# Altium schematic sheet Main.SchDoc
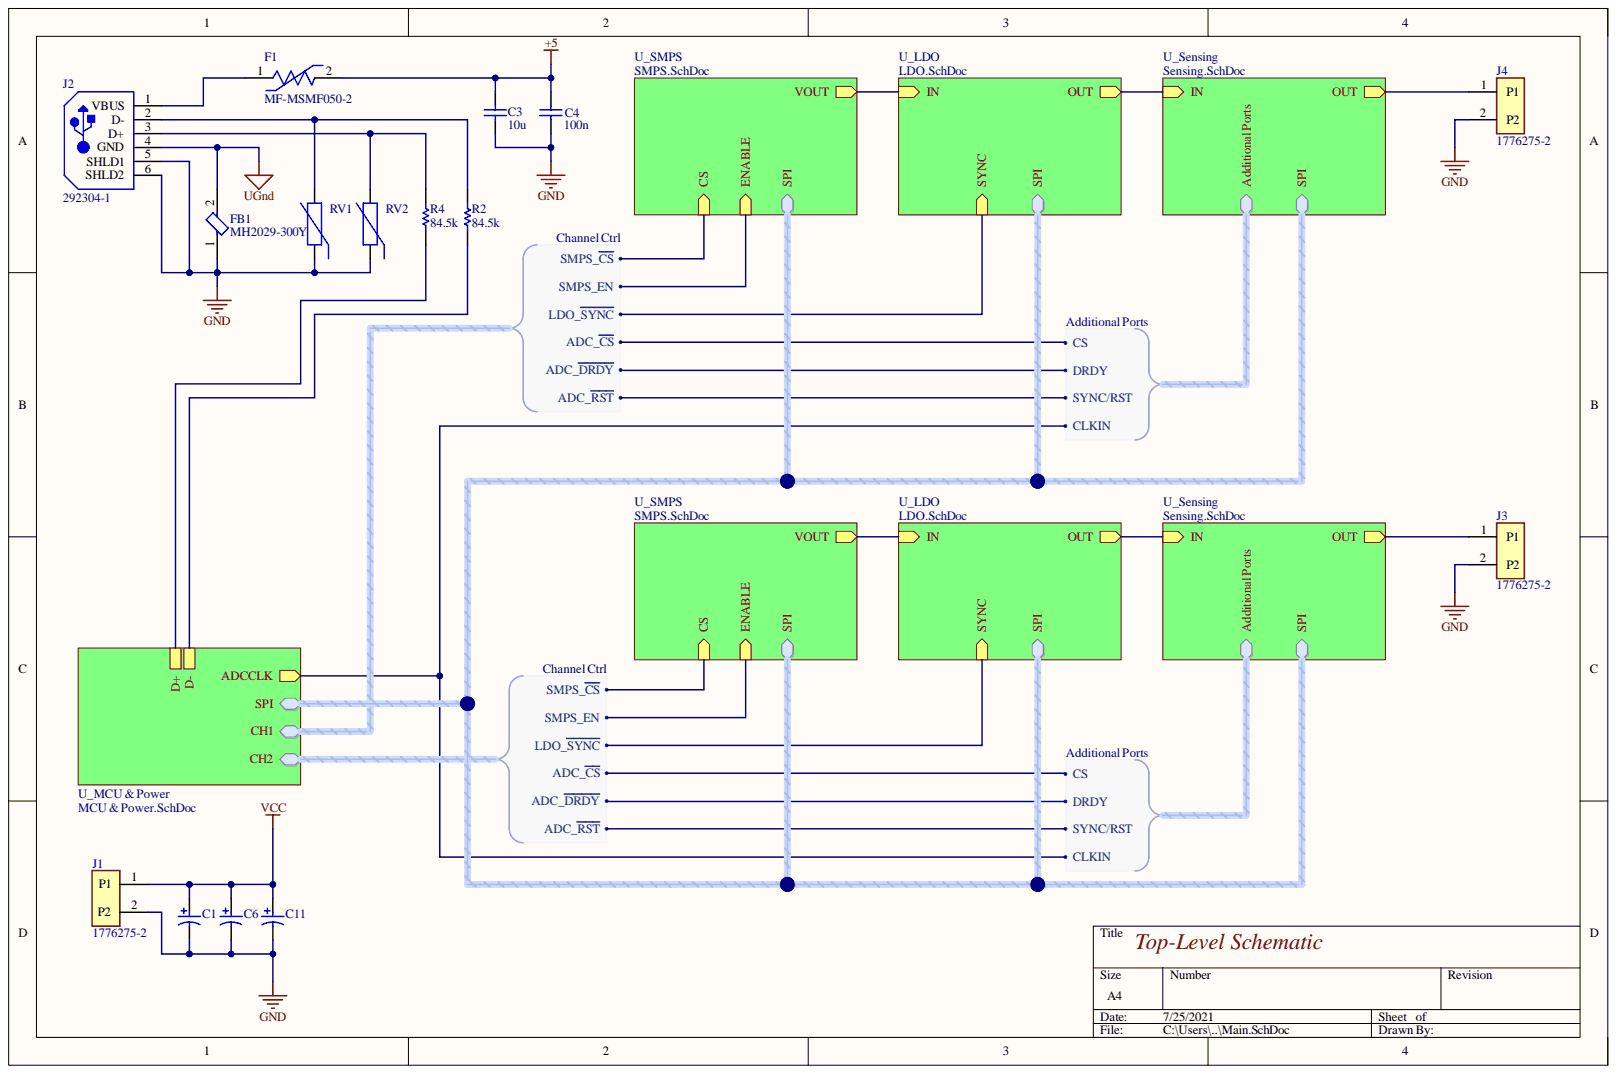
[diagram p0: Main.SchDoc]

## Parts
C1  ECA-1JHG471  [CAPPR65-500-2000X1250X2200]  (value not drawn)
C3  10u  [CAPC3216X180X55LL20T30]
C4  100n  [CAPC2013X145X50LL20T25]
C6  ECA-1JHG471  [CAPPR65-500-2000X1250X2200]  (value not drawn)
C11  ECA-1JHG471  [CAPPR65-500-2000X1250X2200]  (value not drawn)
F1  MF-MSMF050-2  [FP-MF-MSMF050-2-MFG]
FB1  MH2029-300Y  [FP-MH2029-MFG]
J1  1776275-2  [TE_1776275-2]
J2  292304-1  [TECO-292304-1-4_V]
J3  1776275-2  [TE_1776275-2]
J4  1776275-2  [TE_1776275-2]
R2  84.5k  [RESC2013X60X35NL10T20]
R4  84.5k  [RESC2013X60X35NL10T20]
RV1  CG0603MLC-05E  [BOUR-CG0603-2_V]  (value not drawn)
RV2  CG0603MLC-05E  [BOUR-CG0603-2_V]  (value not drawn)

## Nets
+5: C3.2 C4.2 F1.2
GND: C1.2 C3.1 C4.1 C6.2 C11.2 FB1.1 J1.2 J2.5(SHLD1) J2.6(SHLD2) J3.2 J4.2 RV1.1 RV2.1
UGnd: FB1.2 J2.4(GND)
VCC: C1.1 C6.1 C11.1 J1.1
NetF1_1: F1.1 J2.1(VBUS)
NetJ2_2: J2.2(D-) R2.2 RV1.2
NetJ2_3: J2.3(D+) R4.2 RV2.2
NetJ3_1: J3.1
NetJ4_1: J4.1
NetR2_1: R2.1
NetR4_1: R4.1
ADCCLK:
Additional Ports.CS:
Additional Ports.DRDY:
Additional Ports.SYNC/RST:
CH1.LDO_~{SYNC}:
CH1.SMPS_~{CS}:
CH1.SMPS_EN:
CH2.LDO_~{SYNC}:
CH2.SMPS_~{CS}:
CH2.SMPS_EN:
IN:
SPI.MISO:
SPI.MOSI:
SPI.SCLK:

## Library data of the parts
C1 C6 C11 = CMP-1665-00014-4: Aluminum Electrolytic Capacitor (Radial Lead Type), 470 uF, 63 V, -55 to 105 degC, 2-Pin THD, RoHS, Bulk; Manufacturer: Panasonic; Voltage Rating: 63 V; Component Kind: Standard; ComponentLink1Description: Manufacturer URL; ComponentLink1URL: http://www.panasonic.com/; ComponentLink2Description: Datasheet; ComponentLink2URL: http://industrial.panasonic.com/cdbs/www-data/pdf/RDF0000/ABA0000C1038.pdf; DatasheetVersion: 03/2016; Height: 20 mm; Lead Pitch: 5 mm; Length: 20 mm; Library Name: Altium Content Vault; Max Operating Temperature: 105 degC; Min Operating Temperature: -55 degC; Mount: Through Hole; Mounting Technology: Through Hole; Package Quantity: 200; PackageDescription: 2-Pin Through Hole Device, Dia 12.5 mm, Body Length 20 mm; PackageReference: Radial; Packaging: Bulk; Pins: 2; REACH SVHC: No SVHC; RoHSCompliant: true
C3 = CMP-2000-07261-1: High Value Multilayer Ceramic Capacitor, 10 uF, +/- 10%, 25 V, -55 to 125 degC, 1206 (3216 Metric), RoHS, Tape and Reel; Manufacturer: Taiyo Yuden; Capacitance: 10µF; Catalog Drawings: xMKSeries_1206_1.6; Online Catalog: MSeries; Other Names: 587-2399-6; Temperature Coefficient: X7R; Voltage - Rated: 25V; Applications: GeneralPurpose; Category: Capacitors; Component Kind: Standard; Datasheet URL: http://datasheet.octopart.com/TMK316B7106KL-TD-Taiyo-Yuden-datasheet-62109620.pdf; Datasheet Version: 07/2015; Family: CeramicCapacitors; Features: -; Height - Seated (Max): -; Lead Spacing: -; Lead Style: -; Library Name: Altium Content Vault; Manufacturer URL: http://www.t-yuden.com/; Mounting Technology: SurfaceMount; Mounting Type: SurfaceMount,MLCC; Operating Temperature: -55°C~125°C; Package / Case: 1206(3216Metric); Package Description: 2-Pin Surface Mount Device, Body 3.2 x 1.6 mm; Package Reference: 1206; Packaging: Digi-Reel®; Ratings: -; Series: M; Size / Dimension: 0.126"Lx0.063"W(3.20mmx1.60mm); Standard Package: 1; Thickness (Max): 0.071"(1.80mm); Tolerance: ±10%
C4 = CMP-2007-01136-1: Manufacturer: Kemet; Part Number: C0805C104K3RALTU; Component Kind: Standard; Library Name: Altium Content Vault
F1 = CMP-2100-03653-2: PTC RESET FUSE 15V 500MA 1812; Manufacturer: Bourns; Automotive Grade: Grade 3; Current Rating: 100A; Hold Current: 0.5A; Resistance: 0.15-1Ω; Trip Current: 1A; Trip Time: 0.15s; Value: 0.15-1Ω; Ambient Temperature Range High: +85°C; Ambient Temperature Range Low: -40°C; Automotive: Yes; Category: Fuse; Component Kind: Standard; ComponentLink2Description: Datasheet; ComponentLink2URL: http://www.bourns.com/docs/Product-Datasheets/mfmsmf.pdf; Device Class L1: Circuit Protection; Device Class L2: PTC Resettable Fuses; Device Class L3: unset; Digikey Description: PTC RESET FUSE 15V 500MA 1812; Height: 0.85mm; Lead Free: Yes; Library Name: Altium Content Vault; Manufacturer Part Number: MF-MSMF050-2; Mouser Description: PTC Resettable Fuse 0.5A(hold) 1A(trip) 15V 100A 0.8W 0.15s 0.15Ohm SMD Solder Pad 1812 T/R; Octopart: https://octopart.com/mf-msmf050-2-bourns-28047; Package: 1812; RoHS: TRUE; Temperature: -40°C to +85°C; Temperature Range High: +85°C; Temperature Range Low: -40°C; Voltage Rating: 15V
FB1 = CMP-07252-000001-1: FERRITE BEAD 30 OHM 0805 1LN; Manufacturer: Bourns; Impedance At Frequency: 30Ω @ 100MHz; Resistance: 30Ω; Rms Current: 3A; Series Resistance: 25mΩ; Tolerance: 25%; Value: 30Ω; Automotive: No; Category: FBead; Component Kind: Standard; ComponentLink2Description: Datasheet; ComponentLink2URL: https://www.bourns.com/docs/Product-Datasheets/mh.pdf; Core Material: Ferrite; Device Class L1: Passive Components; Device Class L2: EMI / RFI Components; Device Class L3: Ferrite Beads and Chips; Digikey Description: FERRITE BEAD 30 OHM 0805 1LN; Height: 1.1mm; Ipc Land Pattern Name: CAPC200120X90; Lead Free: Yes; Library Name: Altium Content Vault; Manufacturer Part Number: MH2029-300Y; Mouser Description: Ferrite Beads 30 ohms 25% HIGH CURRENT; Octopart: https://octopart.com/mh2029-300y-bourns-756929; Package: MH2029; RoHS: TRUE; Temperature: -55°C to +125°C; Temperature Range High: +125°C; Temperature Range Low: -55°C
J1 J3 J4 = 1776275-2: TERM BLOCK 2POS SIDE ENT 3.5MM; MF: {'manufacturer': u'TE Connectivity'}; Availability: Unavailable; Component Kind: Standard; Library Name: SnapEDA_Library.IntLib; MP: 1776275-2; Package: None; Price: None
J2 = CMP-2000-05412-1: 1 Port Right Angle Through Hole Mount Female Type B USB Connector, 4 Position, -55 to 85 degC, RoHS, Tray; Manufacturer: TE Connectivity; Gender: Receptacle; No. of Positions: 4; SVHC: ToBeAdvised; USB Connector Type: USBTypeB; USB Standard: USB2.0; Component Kind: Standard; Connector Mounting: ThroughHole; Contact Material: CopperAlloy; Contact Plating: Gold; Datasheet URL: https://datasheet.ciiva.com/851/eng-cd-292304-d2-851088.pdf; Datasheet Version: Oct-93; Library Name: Altium Content Vault; Manufacturer URL: http://www.te.com/; Orientation: RightAngle; Series: B
R2 R4 = CMP-2001-01285-1: Manufacturer: Yageo; Part Number: RC0805FR-0784K5L; Component Kind: Standard; Library Name: Altium Content Vault
RV1 RV2 = CMP-0584-00001-2: ESD Protector, 5 V Supply, -40 to 85 degC, 1.6 x 0.8 x 0.55 mm SMD, RoHS, Tape and Reel; Manufacturer: Bourns; CO: 0.5 pF; RT: 1 ns; VC: 20 V; VDC: 5 V; VT: 150 V; Component Kind: Standard; ComponentLink1Description: Manufacturer URL; ComponentLink1URL: http://www.bourns.com/; ComponentLink2Description: Datasheet; ComponentLink2URL: http://www.bourns.com/data/global/pdfs/MLC.pdf; DatasheetVersion: Rev. S; Library Name: Altium Content Vault; Mounting Technology: Surface Mount; Operating Temperature: -40 to 85 degC; PackageDescription: 2-Pin SMD, Body 1.6 x 0.8 mm, Pitch 1.52 mm; PackageReference: CG0603-2; Packing: Tape and Reel; PartNumber: CG0603MLC-05E; RoHS: TRUE
